# Altium schematic sheet 03config.SchDoc(03config)
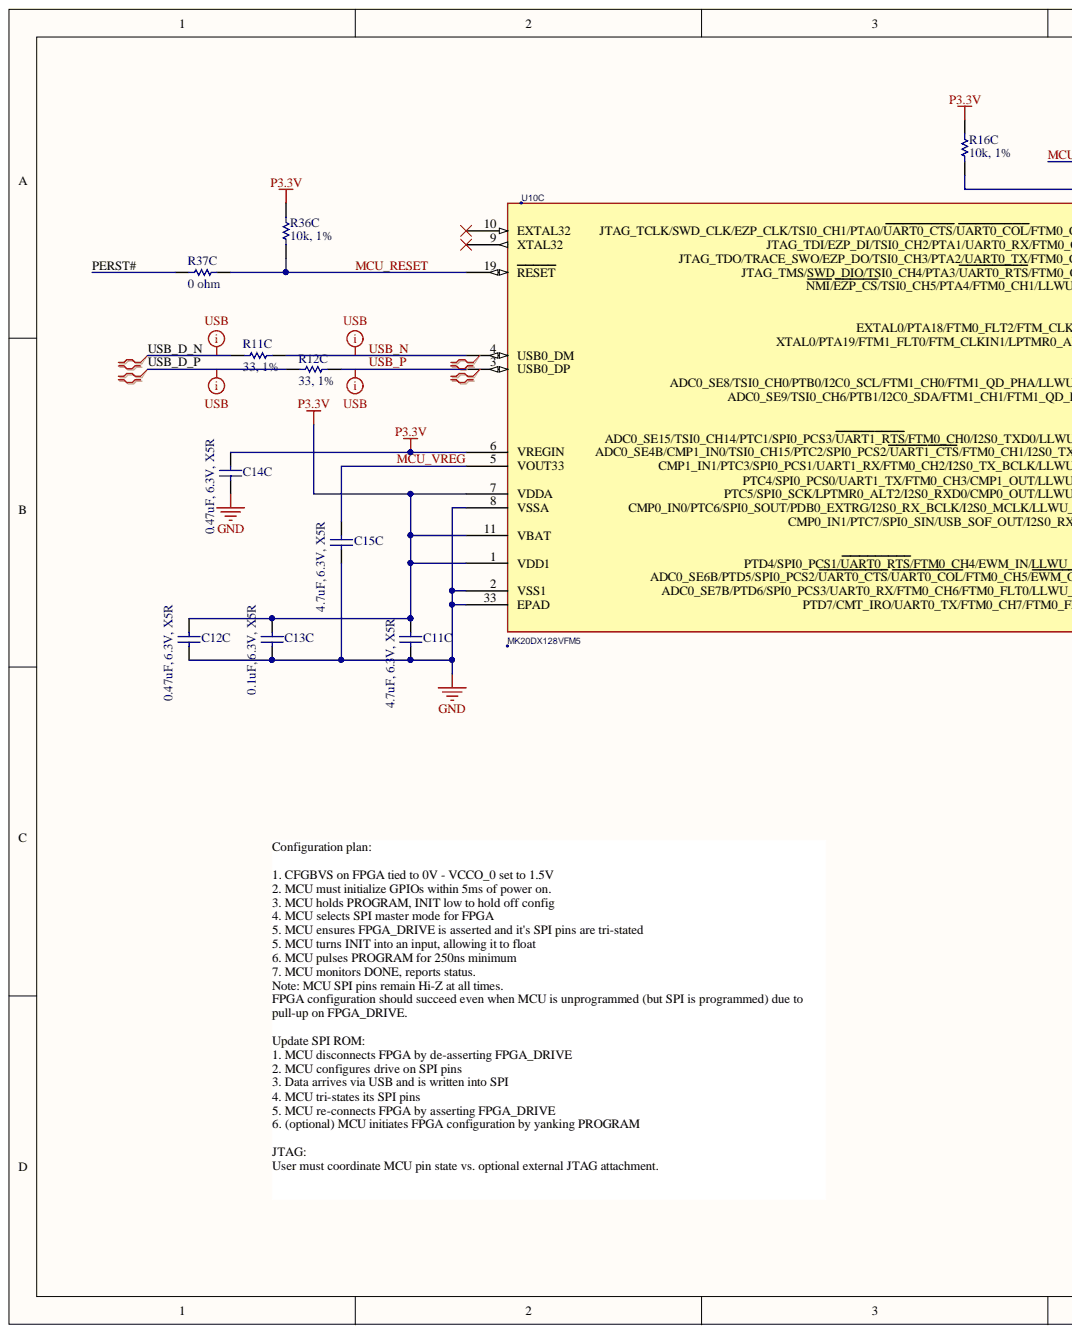
[diagram p0: 03config.SchDoc, left]
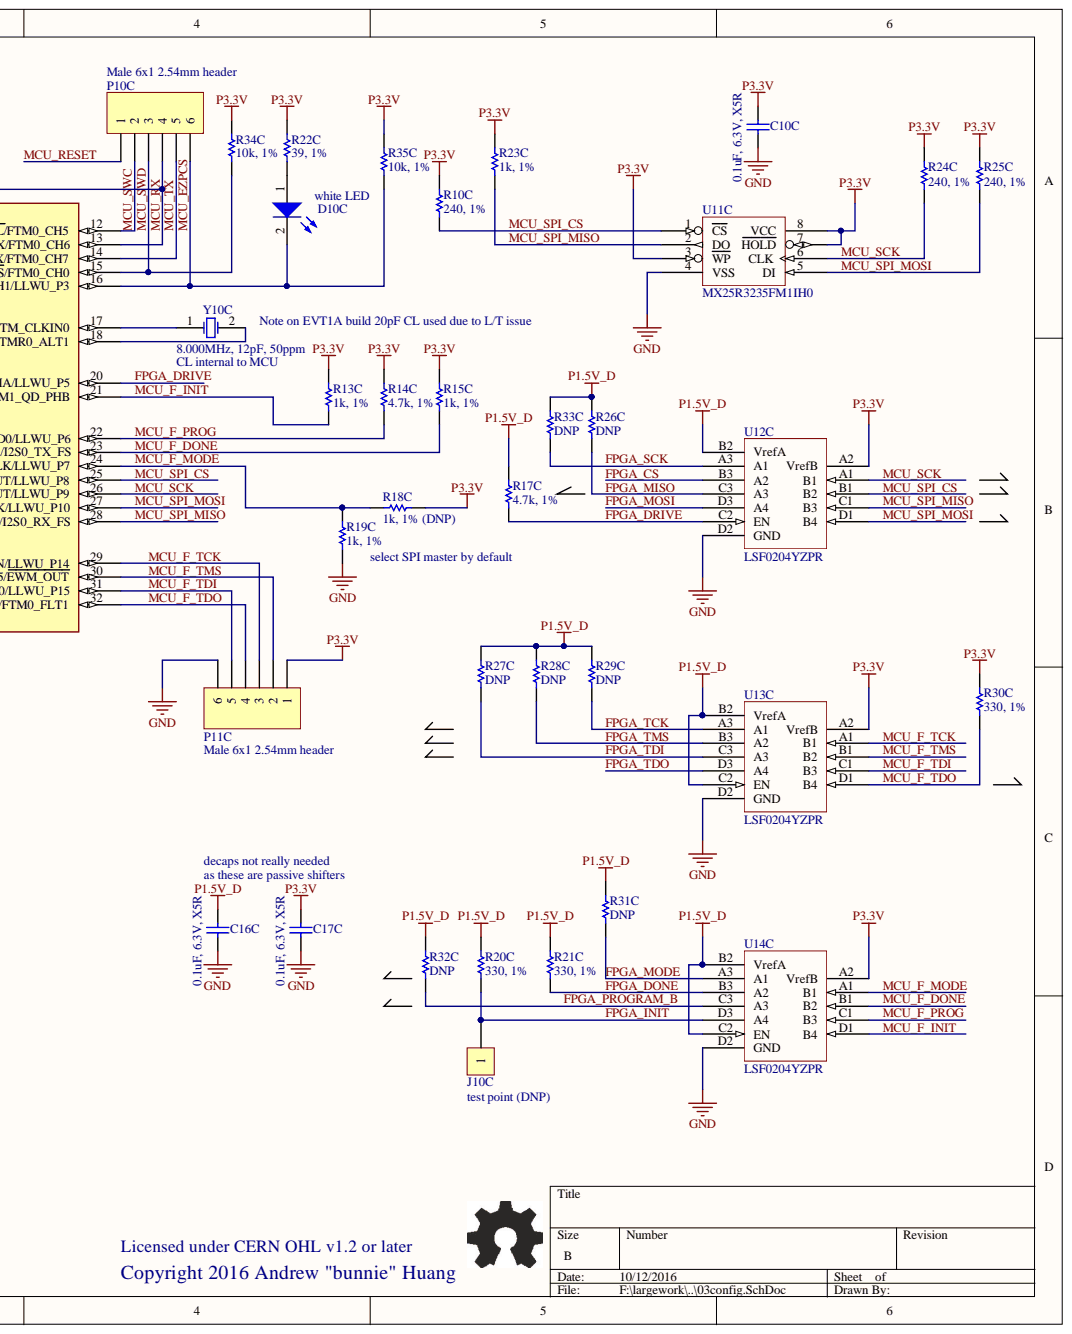
[diagram p1: 03config.SchDoc, right]

## Parts
C10C  0.1uF, 6.3V, X5R  [CAPC0603X03L]
C11C  4.7uF, 6.3V, X5R  [CAPC1005N]
C12C  0.47uF, 6.3V, X5R  [CAPC0603X03L]
C13C  0.1uF, 6.3V, X5R  [CAPC0603X03L]
C14C  0.47uF, 6.3V, X5R  [CAPC0603X03L]
C15C  4.7uF, 6.3V, X5R  [CAPC1005N]
C16C  0.1uF, 6.3V, X5R  [CAPC0603X03L]
C17C  0.1uF, 6.3V, X5R  [CAPC0603X03L]
D10C  white LED  [CD2012-0805]
J10C  test point (DNP)  [TP_SMT_38mil]
P10C  Male 6x1 2.54mm header  [HDR1X6]  (no BOM)
P11C  Male 6x1 2.54mm header  [HDR1X6]  (no BOM)
R10C  240, 1%  [CAPC0603X03L]
R11C  33, 1%  [RESC1005N]
R12C  33, 1%  [RESC1005N]
R13C  1k, 1%  [CAPC0603X03L]
R14C  4.7k, 1%  [RESC1005N]
R15C  1k, 1%  [CAPC0603X03L]
R16C  10k, 1%  [CAPC0603X03L]
R17C  4.7k, 1%  [RESC1005N]
R18C  1k, 1% (DNP)  [RESC1005N]
R19C  1k, 1%  [CAPC0603X03L]
R20C  330, 1%  [CAPC0603X03L]
R21C  330, 1%  [CAPC0603X03L]
R22C  39, 1%  [RESC1005N]
R23C  1k, 1%  [CAPC0603X03L]
R24C  240, 1%  [CAPC0603X03L]
R25C  240, 1%  [CAPC0603X03L]
R26C  DNP  [CAPC0603X03L]
R27C  DNP  [CAPC0603X03L]
R28C  DNP  [CAPC0603X03L]
R29C  DNP  [CAPC0603X03L]
R30C  330, 1%  [CAPC0603X03L]
R31C  DNP  [CAPC0603X03L]
R32C  DNP  [CAPC0603X03L]
R33C  DNP  [CAPC0603X03L]
R34C  10k, 1%  [CAPC0603X03L]
R35C  10k, 1%  [CAPC0603X03L]
R36C  10k, 1%  [CAPC0603X03L]
R37C  0 ohm  [RESC1005N]
U10C  MK20DX128VFM5  [QFN50P500X500X100_HS-33N]
U11C  MX25R3235FM1IH0  [SOIC127P600-8AN]
U12C  LSF0204YZPR  [TI-BGA100P9X9-81A]
U13C  LSF0204YZPR  [TI-BGA100P9X9-81A]
U14C  LSF0204YZPR  [TI-BGA100P9X9-81A]
Y10C  8.000MHz, 12pF, 50ppm  [NDK_NX5032A]

## Nets
GND: C10C.2 C11C.2 C12C.2 C13C.2 C14C.2 C15C.2 C16C.2 C17C.2 P11C.6 R19C.1 U10C.2(VSS1) U10C.8(VSSA) U10C.33(EPAD) U11C.4(VSS) U12C.D2(GND) U13C.D2(GND) U14C.D2(GND)
P1.5V_D: C16C.1 R17C.2 R20C.2 R21C.2 R26C.2 R27C.2 R28C.2 R29C.2 R31C.2 R32C.2 R33C.2 U12C.B2(VrefA) U13C.B2(VrefA) U13C.C2(EN) U14C.B2(VrefA) U14C.C2(EN)
P3.3V: C10C.1 C11C.1 C12C.1 C13C.1 C14C.1 C17C.1 P11C.1 R10C.1 R13C.2 R14C.2 R15C.2 R16C.2 R18C.1 R22C.2 R23C.2 R24C.1 R25C.1 R30C.2 R34C.2 R35C.2 R36C.2 U10C.1(VDD1) U10C.6(VREGIN) U10C.7(VDDA) U10C.11(VBAT) U11C.3(WP) U11C.7(HOLD) U11C.8(VCC) U12C.A2(VrefB) U13C.A2(VrefB) U14C.A2(VrefB)
FPGA_CS: U12C.B3(A2)
FPGA_DONE: R21C.1 U14C.B3(A2)
FPGA_DRIVE: R17C.1 U10C.20(ADC0_SE8/TSI0_CH0/PTB0/I2C0_SCL/FTM1_CH0/FTM1_QD_PHA/LLWU_P5) U12C.C2(EN)
FPGA_INIT: J10C.1 R20C.1 U14C.D3(A4)
FPGA_MISO: R26C.1 U12C.C3(A3)
FPGA_MODE: R31C.1 U14C.A3(A1)
FPGA_MOSI: U12C.D3(A4)
FPGA_PROGRAM_B: R32C.1 U14C.C3(A3)
FPGA_SCK: R33C.1 U12C.A3(A1)
FPGA_TCK: R29C.1 U13C.A3(A1)
FPGA_TDI: R27C.1 U13C.C3(A3)
FPGA_TDO: U13C.D3(A4)
FPGA_TMS: R28C.1 U13C.B3(A2)
MCU_EZPCS: D10C.2 P10C.6 R35C.1 U10C.16(NMI/EZP_CS/TSI0_CH5/PTA4/FTM0_CH1/LLWU_P3)
MCU_F_DONE: R15C.1 U10C.23(ADC0_SE4B/CMP1_IN0/TSI0_CH15/PTC2/SPI0_PCS2/UART1_CTS/FTM0_CH1/I2S0_TX_FS) U14C.B1(B2)
MCU_F_INIT: R13C.1 U10C.21(ADC0_SE9/TSI0_CH6/PTB1/I2C0_SDA/FTM1_CH1/FTM1_QD_PHB) U14C.D1(B4)
MCU_F_MODE: R18C.2 R19C.2 U10C.24(CMP1_IN1/PTC3/SPI0_PCS1/UART1_RX/FTM0_CH2/I2S0_TX_BCLK/LLWU_P7) U14C.A1(B1)
MCU_F_PROG: R14C.1 U10C.22(ADC0_SE15/TSI0_CH14/PTC1/SPI0_PCS3/UART1_RTS/FTM0_CH0/I2S0_TXD0/LLWU_P6) U14C.C1(B3)
MCU_F_TCK: P11C.3 U10C.29(PTD4/SPI0_PCS1/UART0_RTS/FTM0_CH4/EWM_IN/LLWU_P14) U13C.A1(B1)
MCU_F_TDI: P11C.5 U10C.31(ADC0_SE7B/PTD6/SPI0_PCS3/UART0_RX/FTM0_CH6/FTM0_FLT0/LLWU_P15) U13C.C1(B3)
MCU_F_TDO: P11C.4 R30C.1 U10C.32(PTD7/CMT_IRO/UART0_TX/FTM0_CH7/FTM0_FLT1) U13C.D1(B4)
MCU_F_TMS: P11C.2 U10C.30(ADC0_SE6B/PTD5/SPI0_PCS2/UART0_CTS/UART0_COL/FTM0_CH5/EWM_OUT) U13C.B1(B2)
MCU_RESET: P10C.1 R36C.1 R37C.1 U10C.19(RESET)
MCU_RX: P10C.4 R16C.1 U10C.13(JTAG_TDI/EZP_DI/TSI0_CH2/PTA1/UART0_RX/FTM0_CH6)
MCU_SCK: R24C.2 U10C.26(PTC5/SPI0_SCK/LPTMR0_ALT2/I2S0_RXD0/CMP0_OUT/LLWU_P9) U11C.6(CLK) U12C.A1(B1)
MCU_SPI_CS: R10C.2 U10C.25(PTC4/SPI0_PCS0/UART1_TX/FTM0_CH3/CMP1_OUT/LLWU_P8) U11C.1(CS) U12C.B1(B2)
MCU_SPI_MISO: R23C.1 U10C.28(CMP0_IN1/PTC7/SPI0_SIN/USB_SOF_OUT/I2S0_RX_FS) U11C.2(DO) U12C.C1(B3)
MCU_SPI_MOSI: R25C.2 U10C.27(CMP0_IN0/PTC6/SPI0_SOUT/PDB0_EXTRG/I2S0_RX_BCLK/I2S0_MCLK/LLWU_P10) U11C.5(DI) U12C.D1(B4)
MCU_SWC: P10C.2 U10C.12(JTAG_TCLK/SWD_CLK/EZP_CLK/TSI0_CH1/PTA0/UART0_CTS/UART0_COL/FTM0_CH5)
MCU_SWD: P10C.3 R34C.1 U10C.15(JTAG_TMS/SWD_DIO/TSI0_CH4/PTA3/UART0_RTS/FTM0_CH0)
MCU_TX: P10C.5 U10C.14(JTAG_TDO/TRACE_SWO/EZP_DO/TSI0_CH3/PTA2/UART0_TX/FTM0_CH7)
MCU_VREG: C15C.1 U10C.5(VOUT33)
PERST#: R37C.2
USB_D_N: R11C.1
USB_D_P: R12C.1
USB_N: R11C.2 U10C.4(USB0_DM)
USB_P: R12C.2 U10C.3(USB0_DP)
NetD10C_1: D10C.1 R22C.1
NetU10C_17: U10C.17(EXTAL0/PTA18/FTM0_FLT2/FTM_CLKIN0) Y10C.1
NetU10C_18: U10C.18(XTAL0/PTA19/FTM1_FLT0/FTM_CLKIN1/LPTMR0_ALT1) Y10C.2
N00058:
N00059:

Not connected: U10C 9(XTAL32) 10(EXTAL32)

## Library data of the parts
C10C C13C C16C C17C = Cap Semi: Capacitor (Semiconductor SIM Model); MPN: CL03A104KQ3NNNC; MYPN: C-104-6p3-X5R-0201; VENDOR: Samsung; Component Kind: Standard; Library Name: Miscellaneous Devices.IntLib; Signal Integrity: Cap Semi; Simulation: CAP
C11C C15C = Cap Semi: Capacitor (Semiconductor SIM Model); MPN: CL05A475MQ5NQNC; MYPN: C-475-6p3-X5R-0402; VENDOR: Samsung; Component Kind: Standard; Library Name: Miscellaneous Devices.IntLib; Signal Integrity: Cap Semi; Simulation: CAP
C12C C14C = Cap Semi: Capacitor (Semiconductor SIM Model); MPN: CL03A474KQ3NNNH; MYPN: C-474-6p3-X5R-0201; VENDOR: Samsung; Component Kind: Standard; Library Name: Miscellaneous Devices.IntLib; Signal Integrity: Cap Semi; Simulation: CAP
D10C = LED2: Typical RED, GREEN, YELLOW, AMBER GaAs LED; MPN: CB-2012QWC-P8; MYPN: D-LED-WHITE1-0805; VENDOR: CITYBRIGHT; Component Kind: Standard; Library Name: Miscellaneous Devices.IntLib; Simulation: LED2
J10C = CON1: Connector; Component Kind: Standard; MPN: DNP; MYPN: DNP; VENDOR: DNP
P10C P11C = Header 6: Header, 6-Pin; MPN: DNP; MYPN: J-HDR-2.54-6x1-STD-DNP; LatestRevisionDate: 17-Jul-2002; LatestRevisionNote: Re-released for DXP Platform.; Library Name: Miscellaneous Connectors.IntLib; Signal Integrity: Connector; VENDOR: DNP; Publisher: Altium Limited
R10C R24C R25C = Res1: Resistor; MPN: ANY; MYPN: R-241-1P-1_16W-0201; Component Kind: Standard; Library Name: Miscellaneous Devices.IntLib; Signal Integrity: Res; Simulation: RESISTOR; VENDOR: ANY
R11C R12C = Res1: Resistor; MPN: ANY; MYPN: R-330-1P-1_16W-0402; Component Kind: Standard; Library Name: Miscellaneous Devices.IntLib; Signal Integrity: Res; Simulation: RESISTOR; VENDOR: ANY
R13C R15C R19C R23C = Res1: Resistor; MPN: ANY; MYPN: R-102-1P-1_16W-0201; Component Kind: Standard; Library Name: Miscellaneous Devices.IntLib; Signal Integrity: Res; Simulation: RESISTOR; VENDOR: ANY
R14C R17C = Res1: Resistor; MPN: ANY; MYPN: R-472-1P-1_16W-0402; Component Kind: Standard; Library Name: Miscellaneous Devices.IntLib; Signal Integrity: Res; Simulation: RESISTOR; VENDOR: ANY
R16C R34C R35C R36C = Res1: Resistor; MPN: ANY; MYPN: R-103-1P-1_16W-0201; Component Kind: Standard; Library Name: Miscellaneous Devices.IntLib; Signal Integrity: Res; Simulation: RESISTOR; VENDOR: ANY
R18C = Res1: Resistor; MYPN: R-102-1P-1_16W-0201-DNP; Component Kind: Standard; Library Name: Miscellaneous Devices.IntLib; MPN: DNP; Signal Integrity: Res; Simulation: RESISTOR; VENDOR: DNP
R20C R21C R30C = Res1: Resistor; MPN: ANY; MYPN: R-331-1P-1_16W-0201; Component Kind: Standard; Library Name: Miscellaneous Devices.IntLib; Signal Integrity: Res; Simulation: RESISTOR; VENDOR: ANY
R22C = Res1: Resistor; MPN: ANY; MYPN: R-390-1P-1_16W-0402; Component Kind: Standard; Library Name: Miscellaneous Devices.IntLib; Signal Integrity: Res; Simulation: RESISTOR; VENDOR: ANY
R26C R27C R28C R29C R31C R32C R33C = Res1: Resistor; MPN: ANY; Component Kind: Standard; Library Name: Miscellaneous Devices.IntLib; MYPN: DNP; Signal Integrity: Res; Simulation: RESISTOR; VENDOR: ANY
R37C = Res1: Resistor; MPN: ANY; MYPN: R-0R0-1P-1_16W-0402; Component Kind: Standard; Library Name: Miscellaneous Devices.IntLib; Signal Integrity: Res; Simulation: RESISTOR; VENDOR: ANY
U10C = MK20DX128VFM5: IC MCU FLASH 128KB 50MHZ 1.71-3.6V QFN32; AGILE_PN: 344-01231; CAD_APPROVED: Yes; MFG_NAME01: FREESCALE SEMICONDUCTOR; MYPN: UMK20DX128VFM5; VENDOR: Freescale; CLASS: IC; Component Kind: Standard; HEIGHT: 1MM; Library Name: commonsch-new.SchLib; MFG_PN01: MK20DX128VFM5; MPN: MK20DX128VFM5; ROHS: Yes
U11C = EN25P05-50GCP: 512 Mbit (64Kbyte) SPI NOR FLASH, Eon Semi; MYPN: UMX25R3235FM1IH0; VENDOR: Macronix; Component Kind: Standard; Library Name: commonsch.lib; MPN: MX25R3235FM1IH0
U12C U13C U14C = LSF0204YZPR: LSF0204x 4-bits bidirectional multi-voltage level translator; MYPN: ULSF0204YZPR; VENDOR: Texas Instruments; Component Kind: Standard; Library Name: commonsch-new.SchLib; MPN: LSF0204YZPR
Y10C = XTAL: Crystal Oscillator; MPN: SJSMD5008M0012F202P; MYPN: X-8MHZ-50322-2P-50PPM; VENDOR: SONGJI; Component Kind: Standard; LatestRevisionDate: 17-Jul-2002; LatestRevisionNote: Re-released for DXP Platform.; Library Name: Miscellaneous Devices.IntLib; PackageReference: R38; Published: 8-Jun-2000; Simulation: XTAL; Publisher: Altium Limited
